# Altium schematic sheet boxer_main.SchDoc
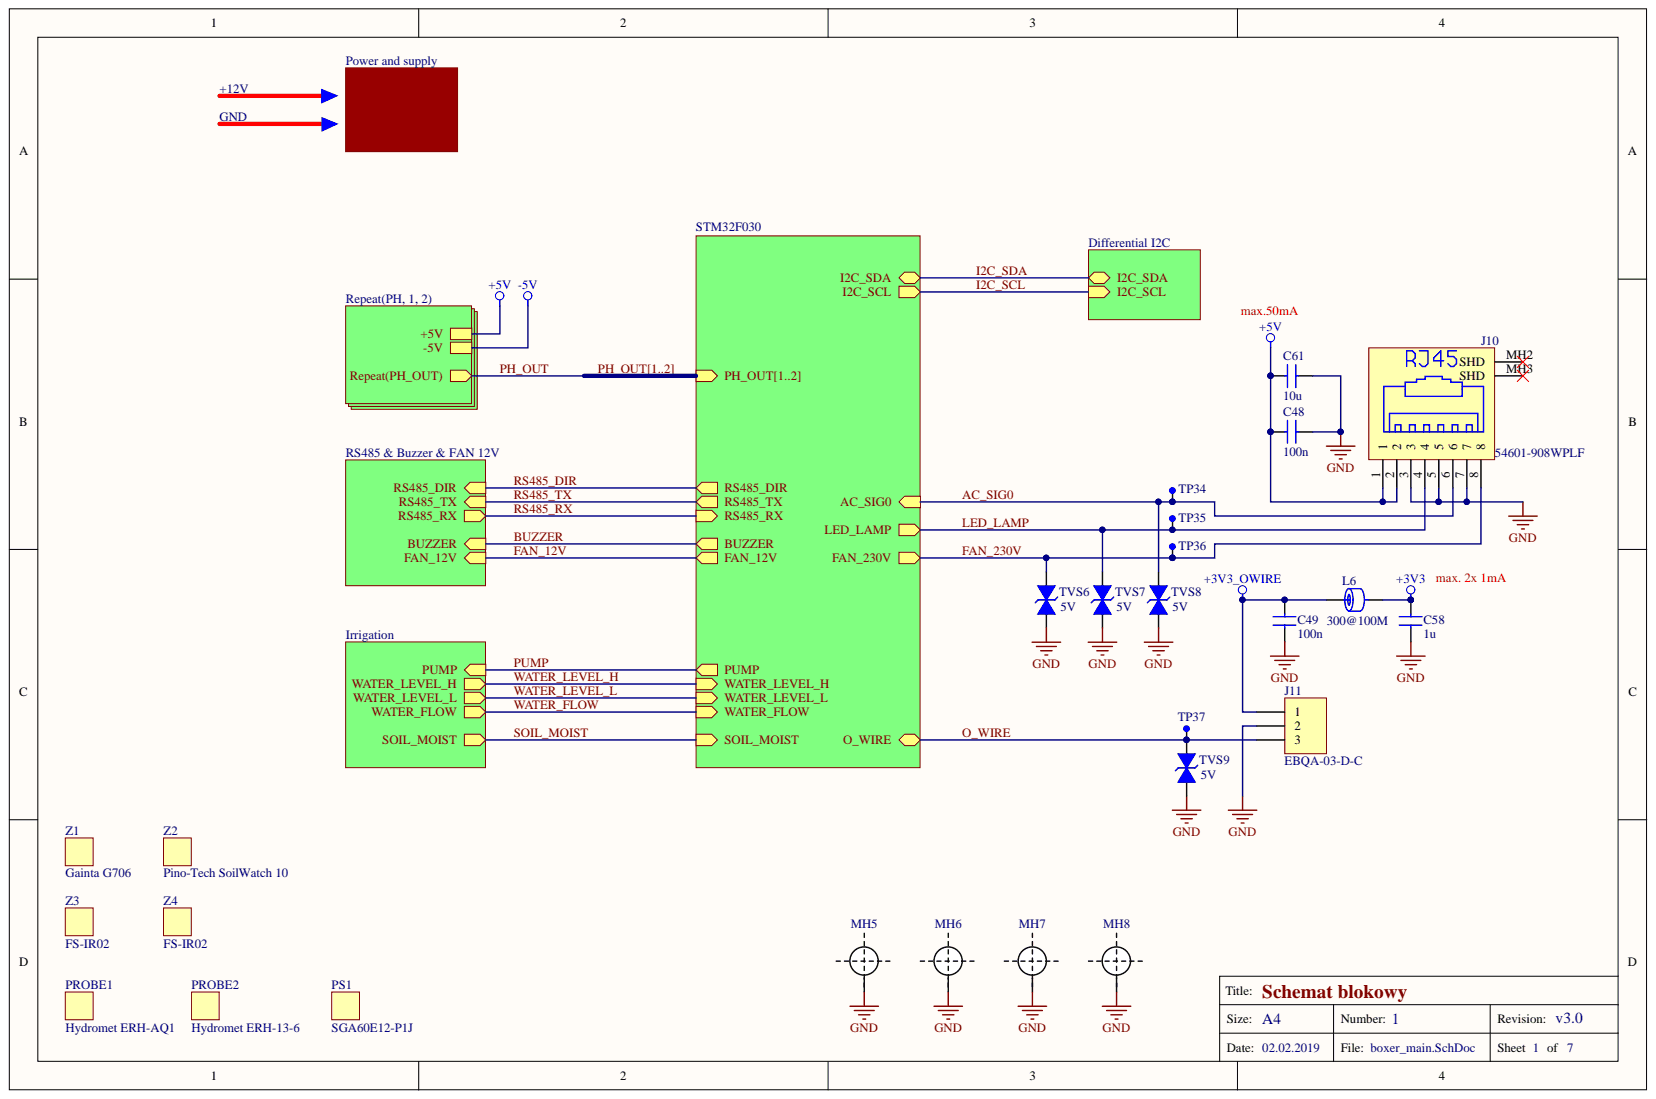
[diagram p0: boxer_main.SchDoc]

## Parts
C48  value 100n  [C_0805]  (hidden comment: Capacitor)
C49  value 100n  [C_0805]  (hidden comment: Capacitor)
C58  value 1u  [C_0805]  (hidden comment: C0805C105K4RACAUTO)
C61  value 10u  [C_1206]  (hidden comment: Capacitor)
J10  54601-908WPLF  [RJ45_54601-908WPLF]
J11  EBQA-03-D-C  [284512-3]
L6  value 300@100M  [L_0805]  (hidden comment: 742792031)
MH5  Mounting hole  [MH_M2.5]  (value not drawn)
MH6  Mounting hole  [MH_M2.5]  (value not drawn)
MH7  Mounting hole  [MH_M2.5]  (value not drawn)
MH8  Mounting hole  [MH_M2.5]  (value not drawn)
PROBE1  Hydromet ERH-AQ1  (mechanical)
PROBE2  Hydromet ERH-13-6  (mechanical)
PS1  SGA60E12-P1J  (mechanical)
TP34  TEST PAD  [PIN]  (value not drawn)
TP35  TEST PAD  [PIN]  (value not drawn)
TP36  TEST PAD  [PIN]  (value not drawn)
TP37  TEST PAD  [PIN]  (value not drawn)
TVS6  value 5V  [D_SMA]  (hidden comment: SMAJ5.0ALFCT-ND)
TVS7  value 5V  [D_SMA]  (hidden comment: SMAJ5.0ALFCT-ND)
TVS8  value 5V  [D_SMA]  (hidden comment: SMAJ5.0ALFCT-ND)
TVS9  value 5V  [D_SMA]  (hidden comment: SMAJ5.0ALFCT-ND)
Z1  Gainta G706  [Gainta G706]
Z2  Pino-Tech SoilWatch 10  (mechanical)
Z3  FS-IR02  (mechanical)
Z4  FS-IR02  (mechanical)

## Nets
+3V3: C58.2 L6.2
+3V3_OWIRE: C49.1 J11.1 L6.1
+5V: C48.2 C61.2 J10.1 J10.2
GND: C48.1 C49.2 C58.1 C61.1 J10.3 J10.5 J10.7 J11.2 MH5.MH MH6.MH MH7.MH MH8.MH TVS6.1 TVS7.1 TVS8.1 TVS9.1
AC_SIG0: J10.6 TP34.1 TVS8.2
FAN_230V: J10.8 TP36.1 TVS6.2
LED_LAMP: J10.4 TP35.1 TVS7.2
O_WIRE: J11.3 TP37.1 TVS9.2
NetJ10_MH2: J10.MH2(SHD)
NetJ10_MH3: J10.MH3(SHD)
-5V:
BUZZER:
FAN_12V:
I2C_SCL:
I2C_SDA:
PH_OUT1:  [also labelled PH_OUT]
PH_OUT2:  [also labelled PH_OUT]
PUMP:
RS485_DIR:
RS485_RX:
RS485_TX:
SOIL_MOIST:
WATER_FLOW:
WATER_LEVEL_H:
WATER_LEVEL_L:

## Library data of the parts
C58 = Capacitor: Component Kind: Standard; Description: Capacitor; Simulation: CAP; Library Name: boxer_pas.SchLib
J10 = RJ45-8: RJ45 Socket, 8pin, No Key, Molex MX-95001-2881; Component Kind: Standard; Value: 54601-908WPLF; Library Name: boxer_mechanical.SchLib
J11 = Header 3: Header, 3-Pin; Component Kind: Standard; Value: EBQA-03-D-C; Library Name: boxer_mechanical.SchLib
L6 = FB: Ferrite Bead; Component Kind: Standard; Simulation: Inductor; Library Name: boxer_pas.SchLib
MH5-MH8 = Mounting hole: Mounting hole 2.3mm; Component Kind: Standard; Library Name: boxer_mechanical.SchLib
PROBE1 = ERH-AQ1: Hydromet ERH-AQ1 Water pH probe; Library Name: boxer_mechanical.SchLib
PROBE2 = ERH-13-6: Hydromet ERH-AQ1 Soil pH probe; Library Name: boxer_mechanical.SchLib
PS1 = EPS-45-12: Library Name: boxer_mechanical.SchLib
TP34-TP37 = TEST_PAD: Component Kind: Standard; Library Name: boxer_mechanical.SchLib
TVS6-TVS9 = Transil: Component Kind: Standard; Description: Transil; Library Name: boxer_pas.SchLib
Z1 = ENCLOSURE: Component Kind: Standard; Library Name: boxer_mechanical.SchLib
Z2 = SoilWatch10: Capacitance Water Soil Sensor, 75MHz; Vcc - 3,1- 5VDC; Vout - analog 0-3VDC; Ioutmax = 24mA; Temp. = 2*C - 45*C; IP67; Library Name: boxer_mechanical.SchLib
Z3 Z4 = FS-IR02: IR Liquid Level Sensor, Vcc 5VDC; Iout = 12mA; Temp. = -25*C - 105*C; Library Name: boxer_mechanical.SchLib
C48 C49 C61 = Capacitor: Component Kind: Standard; Description: Capacitor; Simulation: CAP; Library Name: boxer_pas.SchLib
